# Altium schematic sheet STM32_Core.SchDoc(STM32_Core)
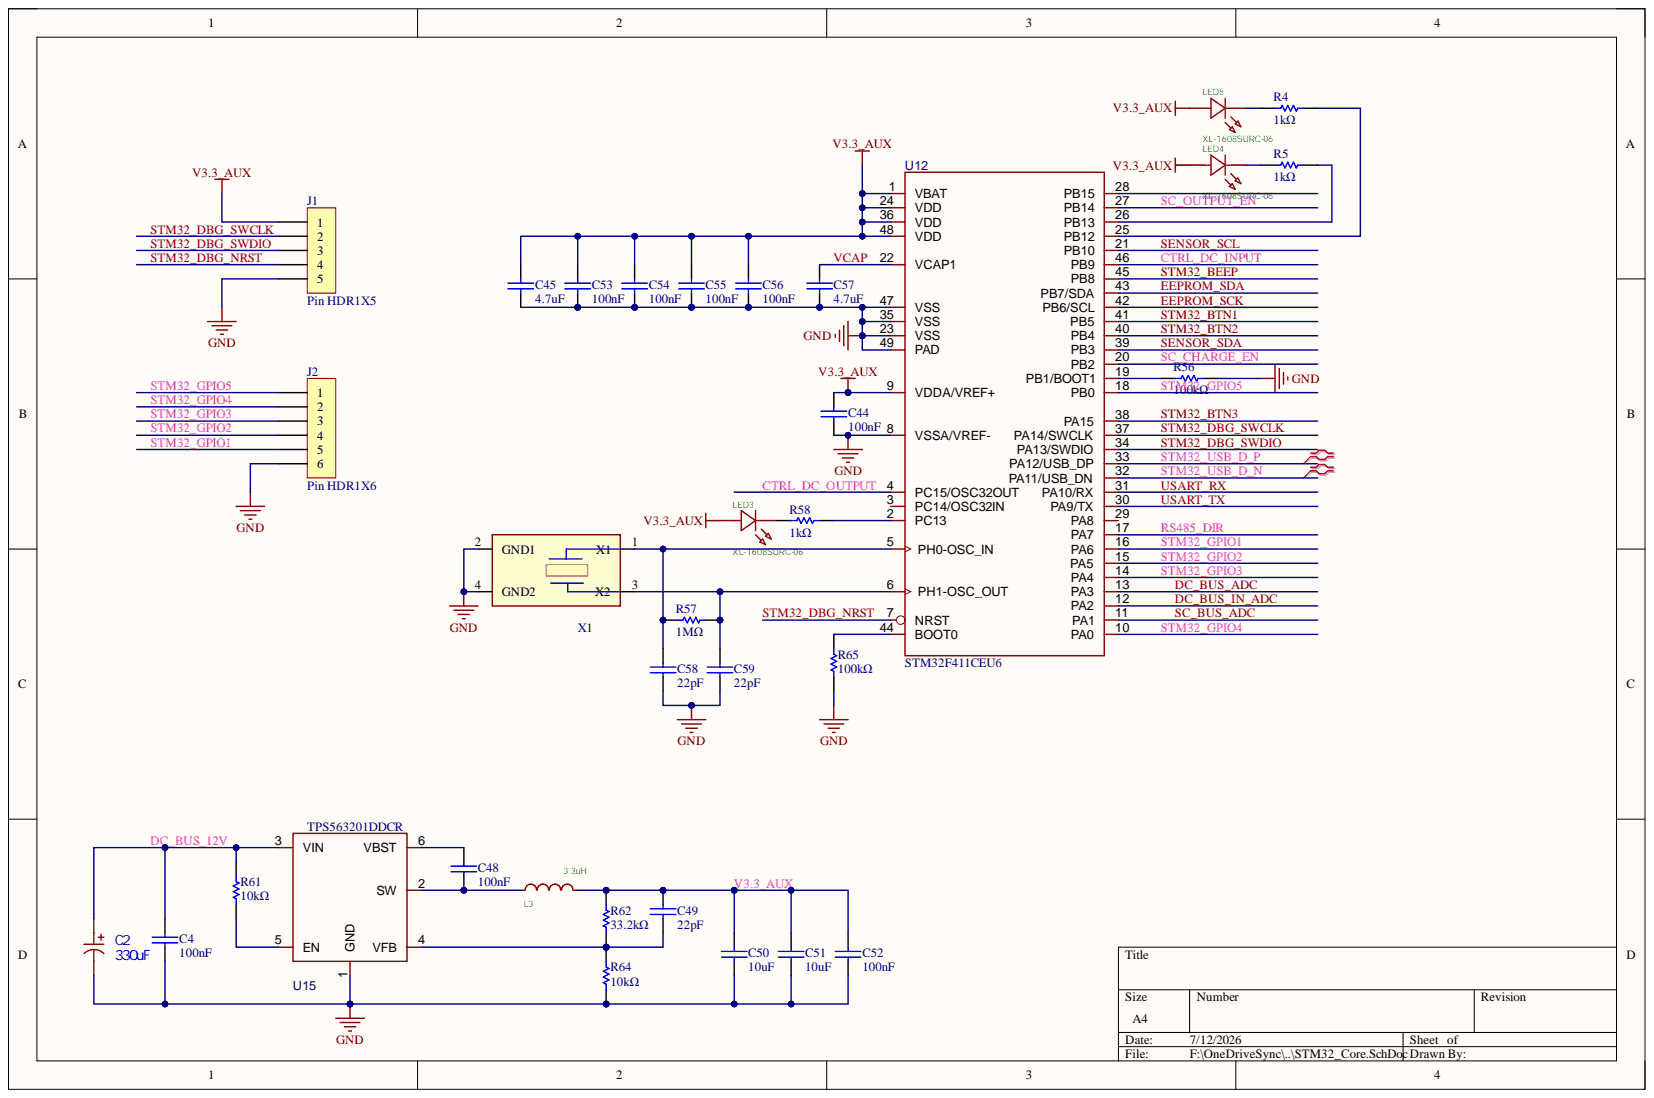
[diagram p0: STM32_Core.SchDoc]

## Parts
C2  330uF  [CAP-SMD_BD8.0-L8.3-W8.3-FD]
C4  100nF  [0603C]
C44  100nF  [0402C]
C45  4.7uF  [0402C]
C48  100nF  [0603C]
C49  22pF  [0402C]
C50  10uF  [0805C]
C51  10uF  [0805C]
C52  100nF  [0603C]
C53  100nF  [0402C]
C54  100nF  [0402C]
C55  100nF  [0402C]
C56  100nF  [0402C]
C57  4.7uF  [0402C]
C58  22pF  [0402C]
C59  22pF  [0402C]
J1  Pin HDR1X5  [Pin HDR1X5/2.54mm-S]
J2  Pin HDR1X6  [Pin HDR1X6/2.54mm-S]
L3  3.3uH  [IND-SMD_L4.4-W4.2]
LED3  XL-1608SURC-06  [LED0603-RD_RED]
LED4  XL-1608SURC-06  [LED0603-RD_RED]
LED5  XL-1608SURC-06  [LED0603-RD_RED]
R4  1kΩ  [0402R]
R5  1kΩ  [0402R]
R56  100kΩ  [0402R]
R57  1MΩ  [0402R]
R58  1kΩ  [0402R]
R61  10kΩ  [0402R]
R62  33.2kΩ  [0402R]
R64  10kΩ  [0402R]
R65  100kΩ  [0402R]
U12  STM32F411CEU6  [UFQFPN-48_L7.0-W7.0-P0.50-BL-EP]
U15  TPS563201DDCR  [SOT-23-6_L2.9-W1.6-P0.95-LS2.8-BL]
X1  OCS_25M_nopower  [CRYSTAL-SMD_4P-L3.2-W2.5-BL]  (value not drawn)

## Nets
GND: C2.2 C4.1 C44.1 C45.1 C50.1 C51.1 C52.1 C53.1 C54.1 C55.1 C56.1 C57.1 C58.1 C59.1 J1.5 J2.6 R56.1 R64.1 R65.2 U12.8(VSSA/VREF-) U12.23(VSS) U12.35(VSS) U12.47(VSS) U12.49(PAD) U15.1(GND) X1.2(GND1) X1.4(GND2)
CTRL_DC_INPUT: U12.46(PB9)
CTRL_DC_OUTPUT: U12.4(PC15/OSC32OUT)
DC_BUS_12V: C2.1 C4.2 R61.2 U15.3(VIN)
DC_BUS_ADC: U12.13(PA3)
DC_BUS_IN_ADC: U12.12(PA2)
EEPROM_SCK: U12.42(PB6/SCL)
EEPROM_SDA: U12.43(PB7/SDA)
RS485_DIR: U12.17(PA7)
SC_BUS_ADC: U12.11(PA1)
SC_CHARGE_EN: U12.20(PB2)
SC_OUTPUT_EN: U12.27(PB14)
SENSOR_SCL: U12.21(PB10)
SENSOR_SDA: U12.39(PB3)
STM32_BEEP: U12.45(PB8)
STM32_BTN1: U12.41(PB5)
STM32_BTN2: U12.40(PB4)
STM32_BTN3: U12.38(PA15)
STM32_DBG_NRST: J1.4 U12.7(NRST)
STM32_DBG_SWCLK: J1.2 U12.37(PA14/SWCLK)
STM32_DBG_SWDIO: J1.3 U12.34(PA13/SWDIO)
STM32_GPIO1: J2.5 U12.16(PA6)
STM32_GPIO2: J2.4 U12.15(PA5)
STM32_GPIO3: J2.3 U12.14(PA4)
STM32_GPIO4: J2.2 U12.10(PA0)
STM32_GPIO5: J2.1 U12.18(PB0)
STM32_USB_D_N: U12.32(PA11/USB_DN)
STM32_USB_D_P: U12.33(PA12/USB_DP)
USART_RX: U12.31(PA10/RX)
USART_TX: U12.30(PA9/TX)
V3.3_AUX: C44.2 C45.2 C49.1 C50.2 C51.2 C52.2 C53.2 C54.2 C55.2 C56.2 J1.1 L3.2 LED3.2 LED4.2 LED5.2 R62.2 U12.1(VBAT) U12.9(VDDA/VREF+) U12.24(VDD) U12.36(VDD) U12.48(VDD)
VCAP: C57.2 U12.22(VCAP1)
NetC48_1: C48.1 L3.1 U15.2(SW)
NetC48_2: C48.2 U15.6(VBST)
NetC49_2: C49.2 R62.1 R64.2 U15.4(VFB)
NetC58_2: C58.2 R57.1 U12.5(PH0-OSC_IN) X1.1
NetC59_2: C59.2 R57.2 U12.6(PH1-OSC_OUT) X1.3(X2)
NetLED3_1: LED3.1 R58.1
NetLED4_1: LED4.1 R5.1
NetLED5_1: LED5.1 R4.1
NetR4_2: R4.2 U12.25(PB12)
NetR5_2: R5.2 U12.26(PB13)
NetR56_2: R56.2 U12.19(PB1/BOOT1)
NetR58_2: R58.2 U12.2(PC13)
NetR61_1: R61.1 U15.5(EN)
NetR65_1: R65.1 U12.44(BOOT0)

Not connected: U12 3(PC14/OSC32IN) 28(PB15) 29(PA8)

## Library data of the parts
C2 = RVT1E331M0810 330UF 25V: C970701; Manufacturer: DMBJ(振宝佳); Add into BOM: yes; Lifetime: 2800hrs@105℃; Ripple Current: 228mA@120Hz; Tolerance: ±20%; Voltage Rating: 25V; Component Kind: Standard; Convert to PCB: yes; Datasheet: https://item.szlcsc.com/datasheet/RVT1E331M0810%2520330UF%252025V/1060429.html; Device: RVT1E331M0810 330UF 25V; Diameter: 8mm; Equivalent Series Resistance(ESR): -; Height - Seated (Max): 10.2mm; JLCPCB Part Class: 扩展库; LCSC Part Name: 330uF ±20% 25V; Manufacturer Part: RVT1E331M0810 330UF 25V; Operating Temperature: -55℃~+105℃; PCB3D: CAP-SMD_BD8.0-L8.3-W8.3-FD; Supplier: LCSC; Supplier Footprint: SMD,D8xL10.2mm; Supplier Part: C970701; Symbol: RVT1E331M0810 330UF 25V; Value: 330uF
C4 C44 C45 C48-C59 = CAP: 无极性电容; Component Kind: Standard; Library Name: 电容.IntLib
L3 = FXL0630-3R3-M: L; Manufacturer: cjiang(长江微电); Add into BOM: yes; DC Resistance (DCR): 22mΩ; Rated Current: 8.5A; Saturation Current (Isat): 9.5A; Tolerance: ±20%; Type: 一体成型电感; Component Kind: Standard; Convert to PCB: yes; Datasheet: https://atta.szlcsc.com/upload/public/pdf/source/20240426/3189EA8206330E2108542F9E34E540E7.pdf; Device: FXL0630-3R3-M; JLCPCB Part Class: Extended Part; LCSC Part Name: 3.3uH ±20% 9.5A; Manufacturer Part: FXL0630-3R3-M; PCB3D: IND-SMD_L7.0-W6.6-H3.0; Supplier: LCSC; Supplier Footprint: SMD,6.6x7mm; Supplier Part: C167219; Symbol: FXL0630-3R3-M; Value: 3.3uH; Library Name: GMP_PB_Core_Lib.SchLib
LED3-LED5 = XL-1608SURC-06: LED 0603; Manufacturer: XINGLIGHT(成兴光); Add into BOM: yes; Forward Current: 20mA; Illumination Color: 红色; Lens Color: 无色透明透镜; Luminous Intensity: 225mcd; Peak Wavelength: 625nm; Power(Watts): 50mW; Viewing Angle: 120°; Voltage - Forward(Vf): 2.3V; Wavelength - Dominant: 620nm~630nm; Color Temperature: -; Component Kind: Standard; Convert to PCB: yes; Datasheet: https://item.szlcsc.com/datasheet/XL-1608SURC-06/1051408.html; Device: XL-1608SURC-06; Diode Configuration: -; JLCPCB Part Class: Extended Part; Lamp Holder Type: -; LCSC Part Name: 0603红色贴片式发光二极管; Manufacturer Part: XL-1608SURC-06; Mounting Style: 正贴; Operating Temperature: -40℃~+85℃; PCB3D: LED0603-RD_RED; Supplier: LCSC; Supplier Footprint: 0603; Supplier Part: C965799; Symbol: XL-1608SURC-06; Library Name: GMP_PB_Core_Lib.SchLib
R4 R5 R56-R58 R61 R62 R64 R65 = RES: Component Kind: Standard; Library Name: 电阻.IntLib
U12 = STM32F411CEU6: STM32; Component Kind: Standard; Symbol: STM32F411CEU6; Library Name: GMP_PB_Core_Lib.SchLib
U15 = TPS563201DDCR: PWR Buck 4.5~17V -> 0.768~7V, 3A; Component Kind: Standard; Symbol: TPS563201DDCR; Library Name: GMP_PB_Core_Lib.SchLib
X1 = OCS_25M_nopower: OSC passive, 3225; Component Kind: Standard; Library Name: GMP_PB_Core_Lib.SchLib
J1 = Pin HDR1X5: Component Kind: Standard; Library Name: 排针.IntLib
J2 = Pin HDR1X6: Component Kind: Standard; Library Name: 排针.IntLib
